# Altium schematic sheet Power.SchDoc(Power)
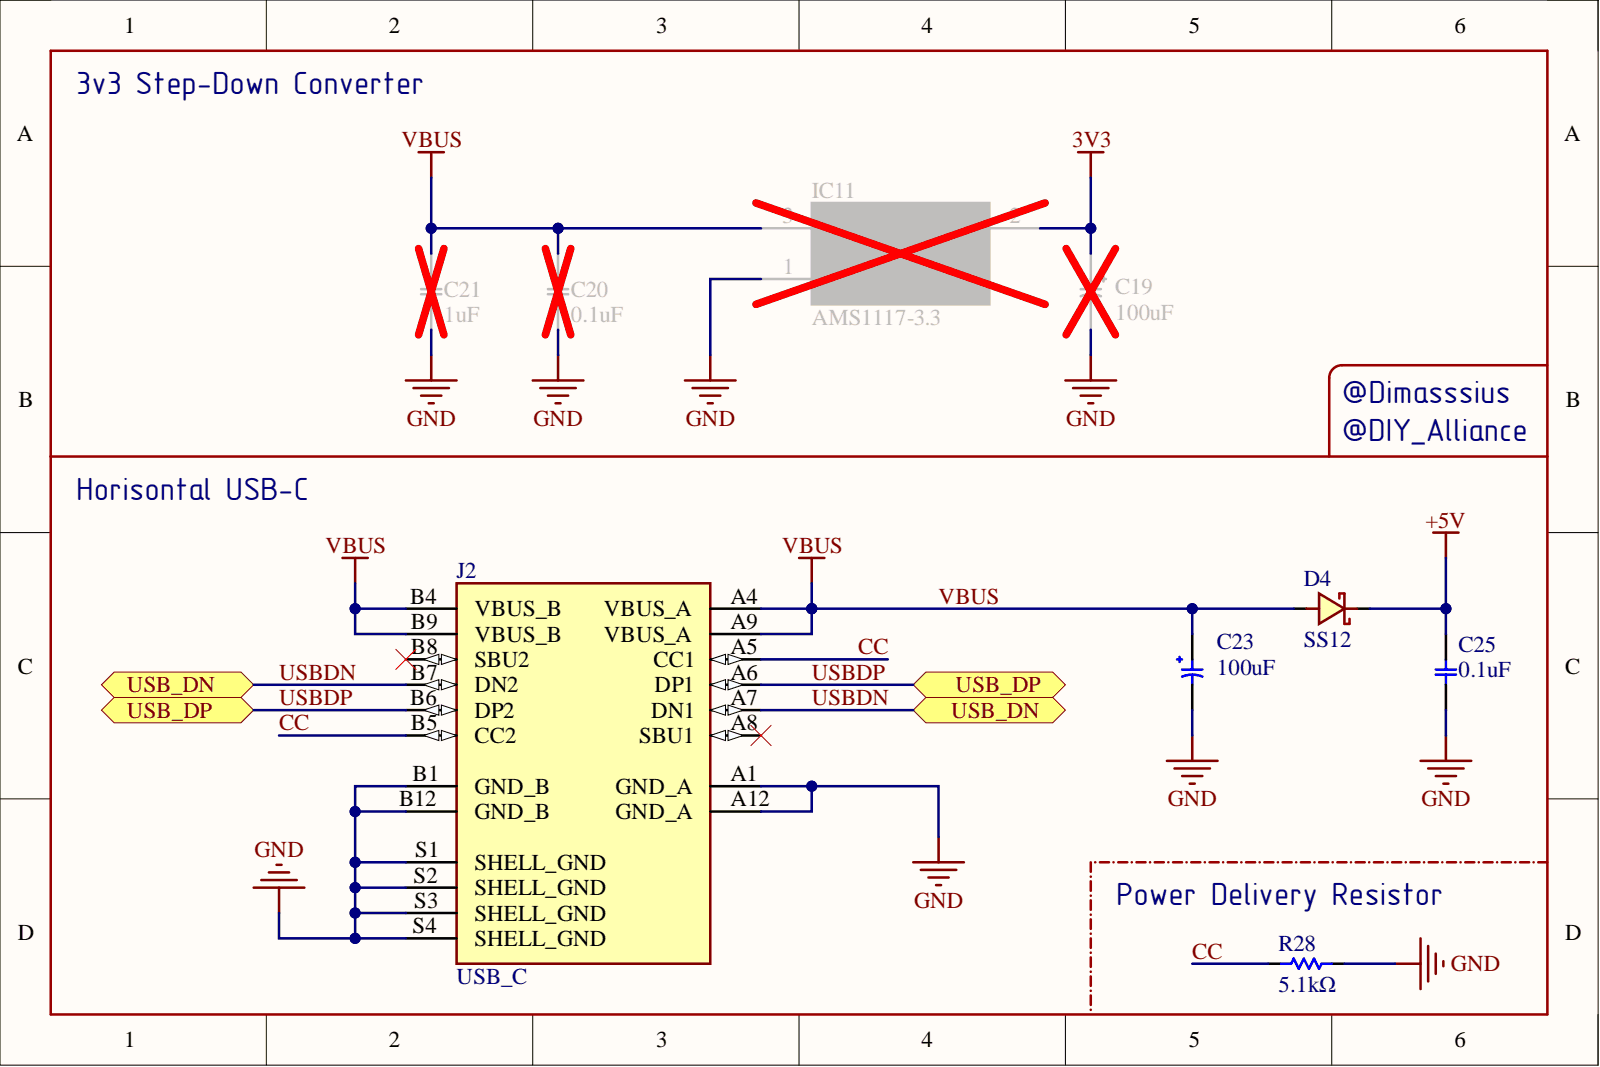
[diagram p0: Power.SchDoc]

## Parts
C19  100uF  [CAP_SMD_ELEC_ALUM_5MM_H5.4MM]
C20  0.1uF  [CAP_SMD_MLCC_C0603_(M1608)_C0402A_(M1005A)]
C21  1uF  [CAP_SMD_MLCC_C0603_(M1608)_C0402A_(M1005A)]
C23  100uF  [CAP_SMD_ELEC_ALUM_5MM_H5.4MM]
C25  0.1uF  [CAP_SMD_MLCC_C0603_(M1608)_C0402A_(M1005A)]
D4  SS12  [DO-214AC]
IC11  AMS1117-3.3  [SOT-89-3]
J2  USB_C  [USB_C_DOUBLE]
R28  5.1kΩ  [RES_SMD_R0603_(M1608)_R0402A_(M1005A)]

## Ports
USB_DN, USB_DP

## Nets
3V3: C19.1 IC11.2
+5V: C25.1 D4.1
GND: C19.2 C20.2 C21.2 C23.2 C25.2 IC11.1 J2.A1(GND_A) J2.A12(GND_A) J2.B1(GND_B) J2.B12(GND_B) J2.S1(SHELL_GND) J2.S2(SHELL_GND) J2.S3(SHELL_GND) J2.S4(SHELL_GND) R28.2
CC: J2.A5(CC1) J2.B5(CC2) R28.1
USBDN: J2.A7(DN1) J2.B7(DN2) ; port USB_DN
USBDP: J2.A6(DP1) J2.B6(DP2) ; port USB_DP
VBUS: C20.1 C21.1 C23.1 D4.2 IC11.3 J2.A4(VBUS_A) J2.A9(VBUS_A) J2.B4(VBUS_B) J2.B9(VBUS_B)

Not connected: J2 A8(SBU1) B8(SBU2)

## Library data of the parts
C19 C23 = CAP_SMD_ELEC_ALUM_100UF_6.3V: Capacitor, Aluminum Electrolytic Capacitor, SMD, D5xL5.4mm, 100uF, 6.3V, ±20%, Aluminum Electrolytic Capacitors - SMD, ROHS; Component Kind: Standard; Library Name: Capacitors.SchLib; Value: 100uF
C20 C25 = CAP_SMD_MLCC_0.1UF_6.3V: Capacitor, Ceramic (MLCC), SMD, 100nF, 6.3V, ±10%, X7R, Multilayer Ceramic Capacitors MLCC - SMD/SMT, ROHS; Manufacturer: Murata Electronics North America; Part Number: LLM315R71C104MA11L; @ qty: 0; Minimum Quantity: 1; Tolerance: ±20%; Unit Price (USD): 0.9; Voltage: 16V; Applications: Bypass, Decoupling; Component Kind: Standard; Datasheets: http://www.murata.com/~/media/webrenewal/support/library/catalog/products/capacitor/mlcc/c02e.pdf; Digi-Key Part Number: 490-4495-1-ND; Factory Stock: 0; Features: Low ESL (Multi-Terminal); Height - Seated (Max): -; Image: //media.digikey.com/Renders/Murata%20Renders/1206(LLM315).jpg; Lead Spacing: -; Lead Style: -; Library Name: Capacitors.SchLib; Mounting Type: Surface Mount, MLCC; Operating Temperature: -55°C ~ 125°C; Package / Case: -; Packaging: Cut Tape (CT); Part Status: Active; Quantity Available: 2915; Ratings: -; Series: LLM; Size / Dimension: -; Temperature Coefficient: X7R; Thickness (Max): -; Value: 0.1uF
C21 = CAP_SMD_MLCC_1UF_6.3V: Capacitor, Ceramic (MLCC), SMD, 1uF, 6.3V, ±10%, X5R, Multilayer Ceramic Capacitors MLCC - SMD/SMT, ROHS; Manufacturer: Murata Electronics North America; Part Number: GRM319R61A105KA01D; @ qty: 0; Minimum Quantity: 1; Unit Price (USD): 0.28; Voltage: 10V; Applications: General Purpose; Component Kind: Standard; Datasheets: http://www.murata.com/~/media/webrenewal/support/library/catalog/products/capacitor/mlcc/c02e.pdf; Digi-Key Part Number: 490-11607-1-ND; Factory Stock: 0; Features: -; Height - Seated (Max): -; Image: //media.digikey.com/Renders/Murata%20Renders/GR331BD72E473KW01L.jpg; Lead Spacing: -; Lead Style: -; Library Name: Capacitors.SchLib; Mounting Type: Surface Mount, MLCC; Operating Temperature: -55°C ~ 85°C; Package / Case: -; Packaging: Cut Tape (CT); Part Status: Active; Quantity Available: 3593; Ratings: -; Series: GRM; Size / Dimension: -; Temperature Coefficient: X5R; Thickness (Max): -; Tolerance: ±10%; Value: 1uF
D4 = SS12: Diode Schottky, SMD, SMA(DO-214AC), 20V, 1A,  500mV@1A, Schottky Diodes, ROHS; Component Kind: Standard; Datasheet Link: https://datasheet.datasheetarchive.com/originals/distributors/Datasheets-24/DSA-463612.pdf; Height: 2.5mm; Library Name: SamacSys.SchLib; Value: SS12
IC11 = AMS1117-3.3: Low Drop Out (LDO) Regulator, SOT-223, SOT-89-3, 72dB@(120Hz), 1A, Fixed 3.3V, Positive electrode, 16V, Voltage Regulators - Linear, Low Drop Out (LDO) Regulators, ROHS; Component Kind: Standard; Datasheet Link: https://datasheet.datasheetarchive.com/originals/distributors/Datasheets-28/DSA-550594.pdf; Height: 1.8mm; Library Name: SamacSys.SchLib; Value: AMS1117-3.3
J2 = USB_C: USB Connector, 5A, USB 3.1, 1 Horizontal Attachment, 16P, Female, -25℃~+85℃, Type-C, SMD, USB Connectors, ROHS; Value: USB 3.1; Component Kind: Standard; Library Name: SamacSys.SchLib
R28 = RES_SMD_5.1KΩ: Resistor, Thick Film Resistor, SMD, 5.1kΩ, ±5%, Chip Resistor - Surface Mount, ROHS; Component Kind: Standard; Library Name: Resistors.SchLib; Value: 5.1kΩ
